# Altium schematic sheet Signalight_Power.SchDoc
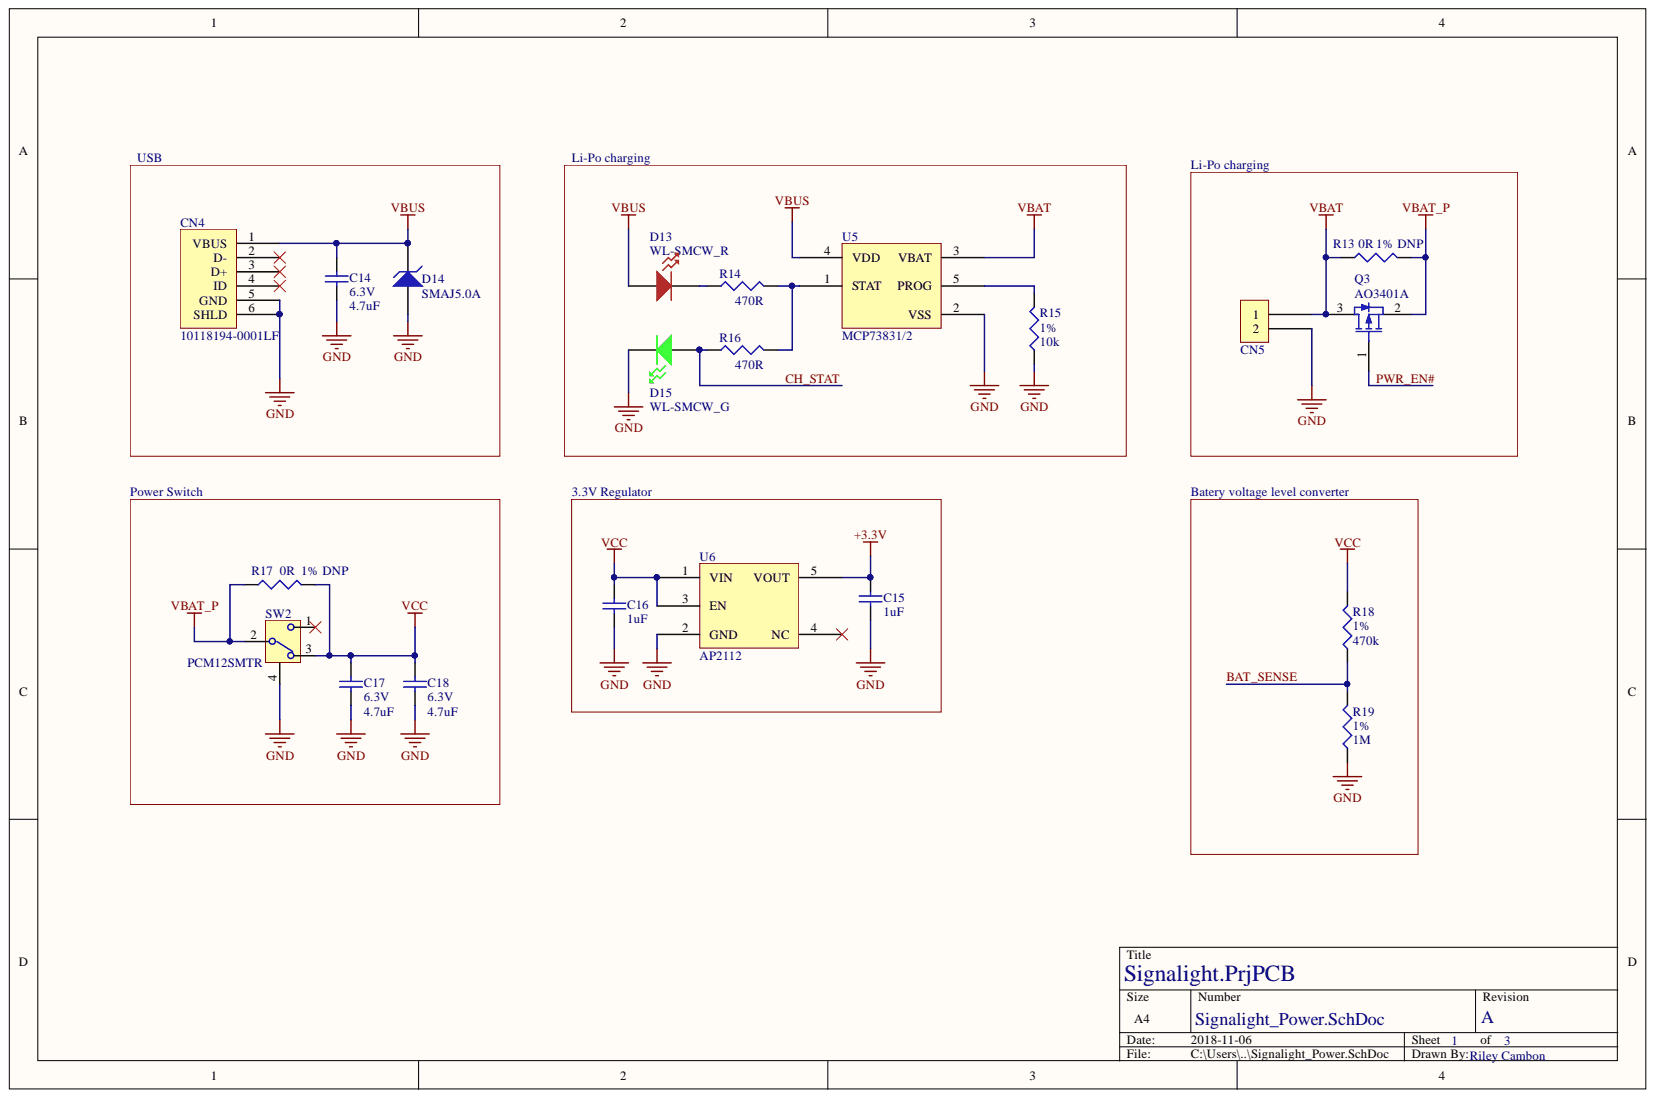
[diagram p0: Signalight_Power.SchDoc]

## Parts
C14  value 4.7uF  Voltage Rating: 6.3V  [TMK107B7105KA-T]  (hidden comment: CAP CER 4.7uF 10% 25V X7R 0603)
C15  value 1uF  [TMK107B7105KA-T]  (hidden comment: CAP CER 1uF 10% 25V X7R 0603)
C16  value 1uF  [TMK107B7105KA-T]  (hidden comment: CAP CER 1uF 10% 25V X7R 0603)
C17  value 4.7uF  Voltage Rating: 6.3V  [TMK107B7105KA-T]  (hidden comment: CAP CER 4.7uF 10% 25V X7R 0603)
C18  value 4.7uF  Voltage Rating: 6.3V  [TMK107B7105KA-T]  (hidden comment: CAP CER 4.7uF 10% 25V X7R 0603)
CN4  USB MICRO B  [10118194-0001LF]  (value not drawn)
CN5  CONN_2PIN_PWR  [CONN_2PIN_POWER]  (value not drawn)
D13  Manufacturer Part Number: WL-SMCW_R  [WL-SMCW - RED]  (hidden comment: WL-SMCW_RED)
D14  SMAJ5.0A  [SMAJ]
D15  Manufacturer Part Number: WL-SMCW_G  [WL-SMCW]  (hidden comment: WL-SMCW_GREEN)
Q3  AO3401A  [AO3401A]
R13  value 0R  Tolerance: 1%  [RES_0603_YAGEO]  (hidden comment: RES 0R 1% 1/10W 0603)
R14  value 470R  [RES_0603_YAGEO]  (hidden comment: RES 470R 1% 1/10W 0603)
R15  value 10k  Tolerance: 1%  [RES_0603_YAGEO]  (hidden comment: RES 10K 1% 1/10W 0603)
R16  value 470R  [RES_0603_YAGEO]  (hidden comment: RES 470R 1% 1/10W 0603)
R17  value 0R  Tolerance: 1%  [RES_0603_YAGEO]  (hidden comment: RES 0R 1% 1/10W 0603)
R18  value 470k  Tolerance: 1%  [RES_0603_YAGEO]  (hidden comment: RES  470k 1% 1/10W 0603_1)
R19  value 1M  Tolerance: 1%  [RES_0603_YAGEO]  (hidden comment: RES  1M 1% 1/10W 0603)
SW2  PCM12SMTR  [PCM12SMTR]
U5  MCP738  Manufacturer Part Number: MCP73831/2  [MCP73811/2]
U6  AP2112  [AP2112 SOT25]

## Nets
+3.3V: C15.1 U6.5(VOUT)
GND: C14.1 C15.2 C16.2 C17.1 C18.1 CN4.5(GND) CN4.6(SHLD) CN5.2 D14.1 D15.2 R15.1 R19.1 SW2.4 U5.2(VSS) U6.2(GND)
VBAT: CN5.1 Q3.3 R13.1 U5.3(VBAT)
VBAT_P: Q3.2 R13.2 R17.1 SW2.2
VBUS: C14.2 CN4.1(VBUS) D13.1 D14.2 U5.4(VDD)
VCC: C16.1 C17.2 C18.2 R17.2 R18.2 SW2.3 U6.1(VIN) U6.3(EN)
BAT_SENSE: R18.1 R19.2
CH_STAT: D15.1 R16.1
PWR_EN#: Q3.1
NetD13_2: D13.2 R14.1
NetR14_2: R14.2 R16.2 U5.1(STAT)
NetR15_2: R15.2 U5.5(PROG)

Not connected: CN4 2(D-) 3(D+) 4(ID); SW2 1; U6 4(NC)

## Library data of the parts
C14 C17 C18 = CAP CER 4.7uF 10% 25V X7R 0603: CAP CER 1uF 10% 25V X7R 0603; Component Kind: Standard; ComponentLink1Description: Datasheet; ComponentLink1URL: http://ds.yuden.co.jp/TYCOMPAS/ut/detail.do?productNo=JMK107BB7475KA-T&fileName=JMK107BB7475KA-T_SS&mode=specSheetDownload; ComponentLink2Description: Digikey; ComponentLink2URL: https://www.digikey.ca/product-detail/en/taiyo-yuden/JMK107BB7475KA-T/587-5870-1-ND/7674990; Library Name: Capacitors.IntLib; Package: 0603; Temp Coefficient: X7R; Tolerance: 10%
CN4 = USB MICRO B: CONN USB MICRO B RECPT SMT R/A; Component Kind: Standard; ComponentLink1Description: Datasheet; ComponentLink1URL: https://cdn.amphenol-icc.com/media/wysiwyg/files/drawing/10118194.pdf; ComponentLink2Description: Digikey; ComponentLink2URL: https://www.digikey.ca/product-detail/en/amphenol-fci/10118194-0001LF/609-4618-1-ND/2785382; Connector Type: USB MICRO B; Library Name: Connectors.IntLib; MPN: 10118194-0001LF
D13 = WL-SMCW_RED: Diode LED RED 20mA 0603; Forward Voltage: 3.2V; Component Kind: Standard; ComponentLink1Description: Datasheet; ComponentLink1URL: http://katalog.we-online.de/led/datasheet/150060RS75000.pdf; ComponentLink2Description: Digikey; ComponentLink2URL: https://www.digikey.com/product-detail/en/wurth-electronics-inc/150060RS75000/732-4978-1-ND/4489899; Library Name: Diodes.IntLib; Package: 0603
D14 = SMAJ5.0A: TVS DIODE 5V 9.2V SMA; Standoff voltage: 6.4V; Component Kind: Standard; ComponentLink1Description: Datasheet; ComponentLink1URL: https://www.diodes.com/assets/Datasheets/ds19005.pdf; ComponentLink2Description: Digikey; ComponentLink2URL: https://www.digikey.ca/product-detail/en/diodes-incorporated/SMAJ5.0A-13-F/SMAJ5.0A-FDICT-ND/816522; Library Name: Diodes.IntLib; Manufactuer Part Number: SMAJ5.0A; Package: SMA
D15 = WL-SMCW_GREEN: Diode LED Green 20mA 0603; Forward Voltage: 3.2V; Component Kind: Standard; ComponentLink1Description: Datasheet; ComponentLink1URL: http://katalog.we-online.de/led/datasheet/150060GS75000.pdf; ComponentLink2Description: Digikey; ComponentLink2URL: https://www.digikey.com/product-detail/en/wurth-electronics-inc/150060GS75000/732-4971-1-ND/4489896; Library Name: Diodes.IntLib; Package: 0603
Q3 = AO3401A: MOSFET P-CH 30V 4A SOT23; Vgs On: 2.5 to 10V; Component Kind: Standard; ComponentLink1Description: Datasheet; ComponentLink1URL: http://aosmd.com/res/data_sheets/AO3401A.pdf; ComponentLink2Description: Digikey; ComponentLink2URL: https://www.digikey.com/product-detail/en/alpha-omega-semiconductor-inc/AO3401A/785-1001-1-ND/1855943; Current Rating: 4A; Library Name: Transistors.IntLib; Manufacturer Part Number: AO3401A; Package: SOT-23-3L; Type: P-Ch; Vdss Max: 30V
R18 = RES  470k 1% 1/10W 0603_1: RES 470k 1% 1/10W 0603; Component Kind: Standard; ComponentLink1Description: Datasheet; ComponentLink1URL: http://www.yageo.com/documents/recent/PYu-RC_Group_51_RoHS_L_8.pdf; ComponentLink2Description: Digikey; ComponentLink2URL: https://www.digikey.ca/product-detail/en/yageo/RC0603FR-07470KL/311-470KHRCT-ND/730204; Library Name: Resistors.IntLib; Power Rating: 1/10W; Size: 0603
R19 = RES  1M 1% 1/10W 0603: RES 1M 1% 1/10W 0603; Component Kind: Standard; ComponentLink1Description: Datasheet; ComponentLink1URL: http://www.yageo.com/documents/recent/PYu-RC_Group_51_RoHS_L_8.pdf; ComponentLink2Description: Digikey; ComponentLink2URL: https://www.digikey.ca/product-detail/en/yageo/RC0603FR-07470RL/311-470HRCT-ND/730203; Library Name: Resistors.IntLib; Power Rating: 1/10W; Size: 0603
SW2 = PCM12SMTR: SWITCH SLIDE SPDT 300MA 6V; Current Rating: 0.3A; Component Kind: Standard; ComponentLink1Description: Datasheet; ComponentLink1URL: https://www.ckswitches.com/media/1424/pcm.pdf; ComponentLink2Description: Digikey; ComponentLink2URL: https://www.digikey.ca/product-detail/en/c-k/PCM12SMTR/401-2016-1-ND/1640125; Library Name: MISC.IntLib; MPN: PCM12SMTR; Voltage Rating: 6V
U5 = MCP738: IC LI-ION/LI-POLY CTRLR SOT23-5; Component Kind: Standard; ComponentLink1Description: Datasheet; ComponentLink1URL: http://ww1.microchip.com/downloads/en/DeviceDoc/20001984g.pdf; ComponentLink2Description: Digikey; ComponentLink2URL: https://www.digikey.ca/product-detail/en/microchip-technology/MCP73831T-2ACI-OT/MCP73831T-2ACI-OTCT-ND/1979802; Library Name: ICs.IntLib; Package: 5-SOT-23
U6 = AP2112: IC REG LINEAR 3.3V 600MA SOT25; Component Kind: Standard; ComponentLink1Description: Datasheet; ComponentLink1URL: https://www.diodes.com/assets/Datasheets/AP2112.pdf; ComponentLink2Description: Digikey; ComponentLink2URL: https://www.digikey.ca/product-detail/en/diodes-incorporated/AP2112K-3.3TRG1/AP2112K-3.3TRG1DICT-ND/4505257; Library Name: ICs.IntLib; Manufacturer Part Number: AP2112; Package: SOT25
C15 C16 = CAP CER 1uF 10% 25V X7R 0603: Component Kind: Standard; ComponentLink1Description: Datasheet; ComponentLink1URL: http://ds.yuden.co.jp/TYCOMPAS/ut/detail.do?productNo=TMK107B7105KA-T&fileName=TMK107B7105KA-T_SS&mode=specSheetDownload; ComponentLink2Description: Digikey; ComponentLink2URL: https://www.digikey.ca/products/en?keywords=1276-1942-1-ND; Description: CAP CER 1uF 10% 25V X7R 0603; Library Name: Capacitors.IntLib; Package: 0603; Temp Coefficient: X7R; Tolerance: 10%; Voltage Rating: 25V
CN5 = CONN_2PIN_PWR: Component Kind: Standard; Description: CONN_2PIN_PWR; Library Name: Connectors.IntLib
R13 R17 = RES 0R 1% 1/10W 0603: Component Kind: Standard; ComponentLink1Description: Datasheet; ComponentLink1URL: http://www.yageo.com/documents/recent/PYu-RC_Group_51_RoHS_L_8.pdf; ComponentLink2Description: Digikey; ComponentLink2URL: https://www.digikey.ca/product-detail/en/yageo/RC0603JR-070RL/311-0.0GRCT-ND/729622; Description: RES 0R 1% 1/10W 0603; Library Name: Resistors.IntLib; Power Rating: 1/10W; Size: 0603
R14 R16 = RES 470R 1% 1/10W 0603: Component Kind: Standard; ComponentLink1Description: Datasheet; ComponentLink1URL: http://www.yageo.com/documents/recent/PYu-RC_Group_51_RoHS_L_8.pdf; ComponentLink2Description: Digikey; ComponentLink2URL: https://www.digikey.ca/product-detail/en/yageo/RC0603FR-07470RL/311-470HRCT-ND/730203; Description: RES 470R 1% 1/10W 0603; Library Name: Resistors.IntLib; Power Rating: 1/10W; Size: 0603; Tolerance: 1%
R15 = RES 10K 1% 1/10W 0603: Component Kind: Standard; ComponentLink1Description: Datasheet; ComponentLink1URL: http://www.yageo.com/documents/recent/PYu-RC_Group_51_RoHS_L_8.pdf; ComponentLink2Description: Digikey; ComponentLink2URL: https://www.digikey.ca/product-detail/en/yageo/RC0603FR-0710KL/311-10.0KHRCT-ND/729827; Description: RES 10K 1% 1/10W 0603; Library Name: Resistors.IntLib; Power Rating: 1/10W; Size: 0603
